# Altium schematic sheet 2002-SCM-0003-08.03 Top Sheet.SchDoc("2002-SCM-0003-08.03 Top Sheet")
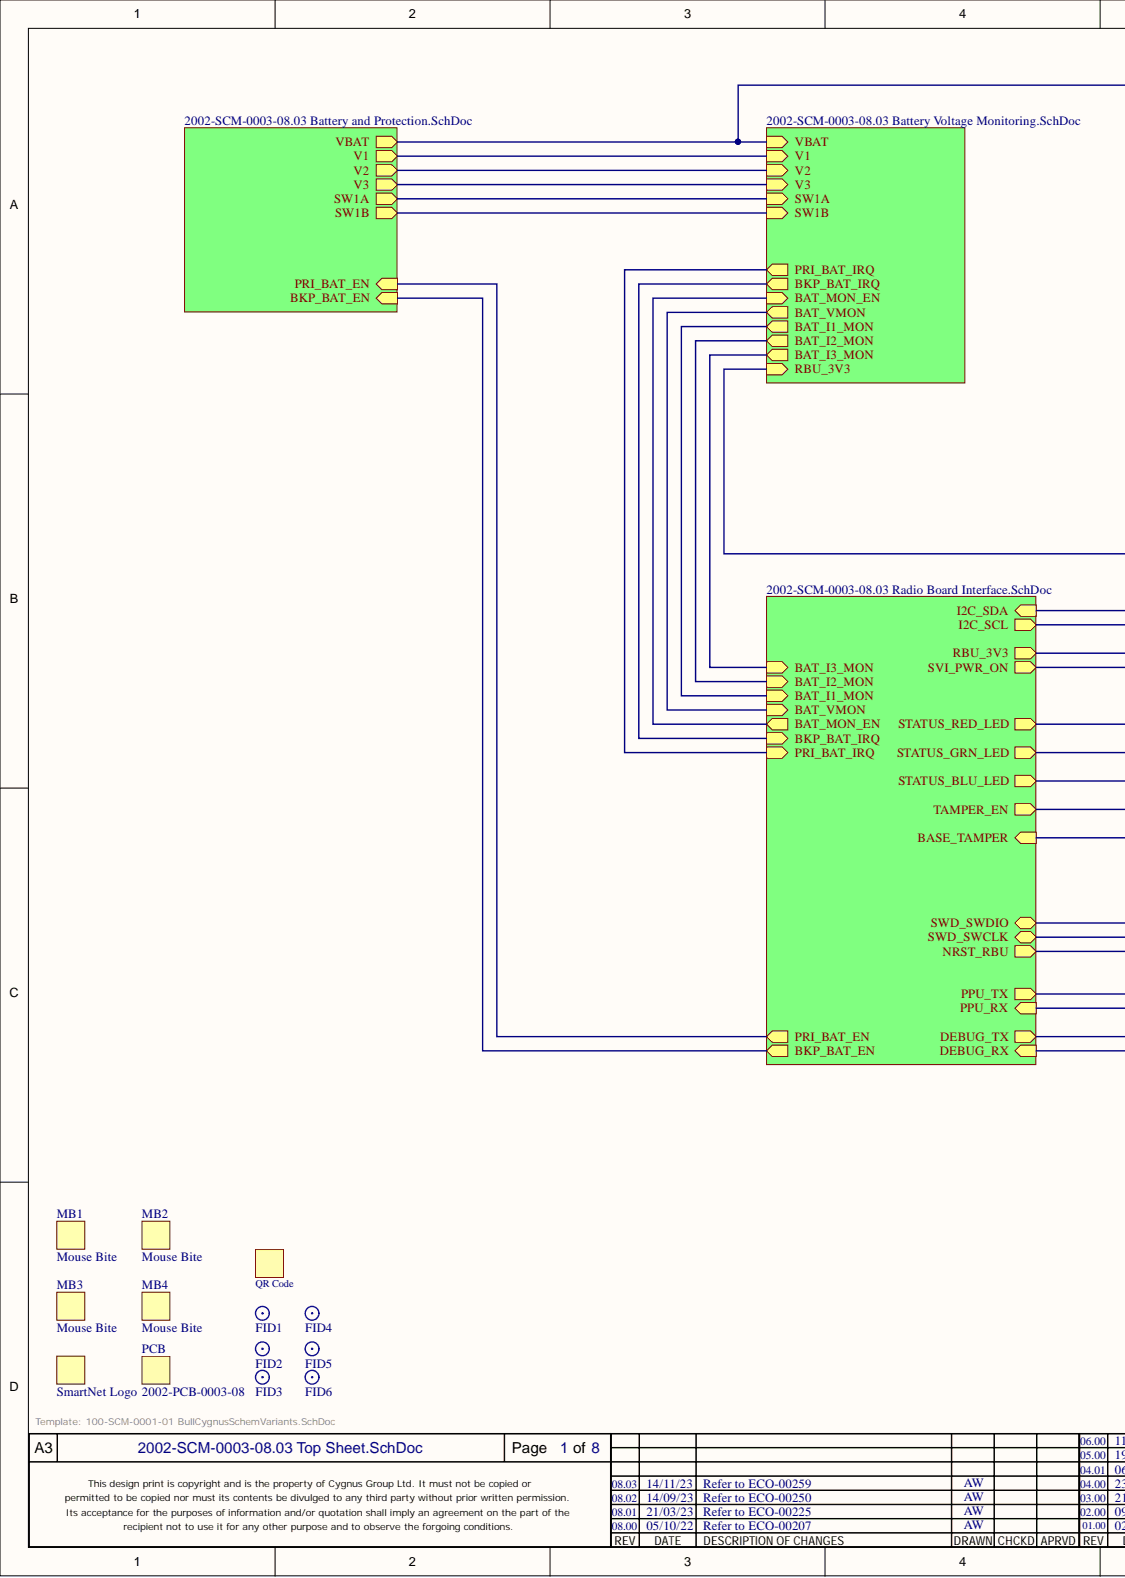
[diagram p0: 2002-SCM-0003-08.03 Top Sheet.SchDoc, left]
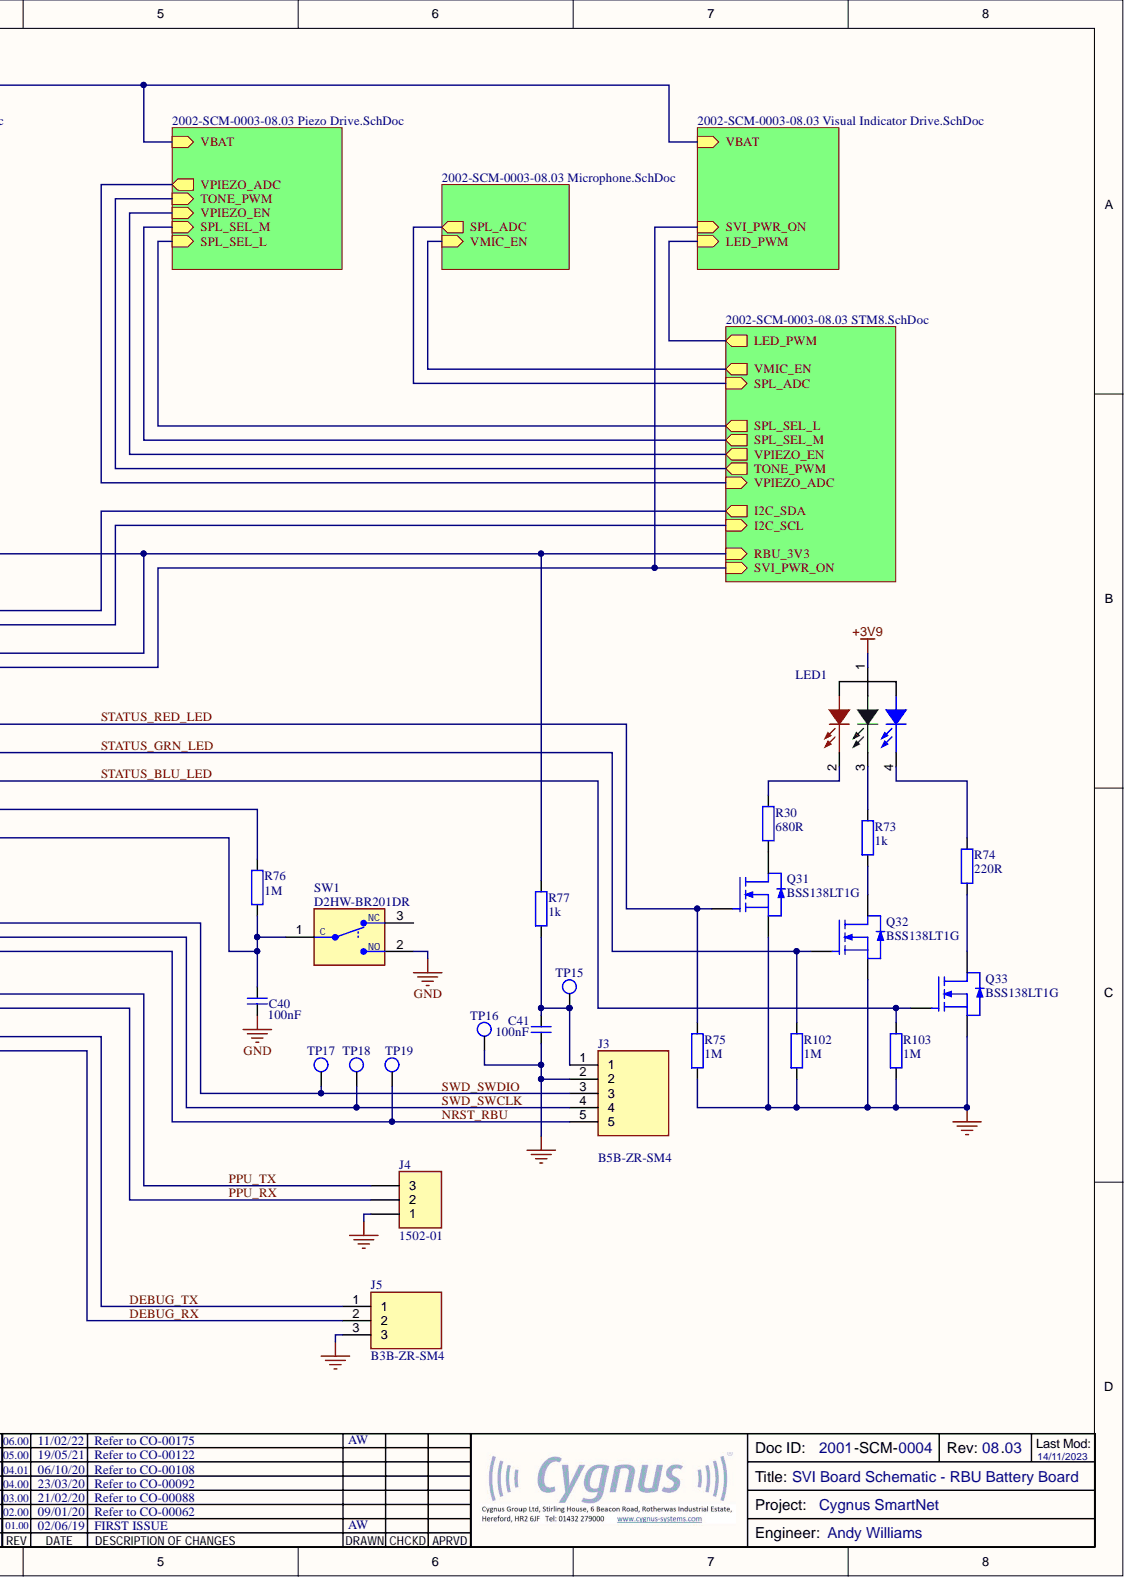
[diagram p1: 2002-SCM-0003-08.03 Top Sheet.SchDoc, right]

## Parts
C40  value 100nF  [CAPC1608X95N]  (hidden comment: 100nF 0603 50V X7R 10%)
C41  value 100nF  [CAPC1608X95N]  (hidden comment: 100nF 0603 50V X7R 10%)
FID1  FIDUCIAL-1MM  [Fiducial 1mm]  (value not drawn)  (no BOM)
FID2  FIDUCIAL-1MM  [Fiducial 1mm]  (value not drawn)  (no BOM)
FID3  FIDUCIAL-1MM  [Fiducial 1mm]  (value not drawn)  (no BOM)
FID4  FIDUCIAL-1MM  [Fiducial 1mm]  (value not drawn)  (no BOM)
FID5  FIDUCIAL-1MM  [Fiducial 1mm]  (value not drawn)  (no BOM)
FID6  FIDUCIAL-1MM  [Fiducial 1mm]  (value not drawn)  (no BOM)
J3  value B5B-ZR-SM4  [CONN-JST-B5B-ZR-SM4-TF]  (hidden comment: JST B5B ZR 5Way SMD)
J4  value 1502-01  [Jack Socket Lumberg 1502-01]  (hidden comment: Jack Socket TH V 3.5mm Lumberg 1502-01)
J5  value B3B-ZR-SM4  [CONN-JST-B3B-ZR-SM4-TF]  (hidden comment: JST B3B ZR 3Way SMD V 1P5)
Label1  SmartNet Logo  [SmartNet Logo]  (no BOM)
LED1  LED RGB PLCC4 SMD  [LED-PLCC4210x210x100]  (value not drawn)
MB1  Mouse Bite  [Mouse Bite]  (no BOM)
MB2  Mouse Bite  [Mouse Bite]  (no BOM)
MB3  Mouse Bite  [Mouse Bite]  (no BOM)
MB4  Mouse Bite  [Mouse Bite]  (no BOM)
PCB  value 2002-PCB-0003-08  (hidden comment: Bare PCB)  (mechanical)
Q31  value BSS138LT1G  [SOT-23L]  (hidden comment: BSS138LT1G N-CH 50V 0.2A SOT23)
Q32  value BSS138LT1G  [SOT-23L]  (hidden comment: BSS138LT1G N-CH 50V 0.2A SOT23)
Q33  value BSS138LT1G  [SOT-23L]  (hidden comment: BSS138LT1G N-CH 50V 0.2A SOT23)
QR_Code  PCB Laser Etched QR Code  [PCB QR CODE]  (value not drawn)  (no BOM)
R30  value 680R  [RESC1608N]  (hidden comment: 680R 0603 100mW 1%)
R73  value 1k  [RESC1608N]  (hidden comment: 1K 0603 100mW 1%)
R74  value 220R  [RESC1608N]  (hidden comment: 220R 0603 100mW 1%)
R75  value 1M  [R1608N]  (hidden comment: 1M 0603 100mW 1%)
R76  value 1M  [R1608N]  (hidden comment: 1M 0603 100mW 1%)
R77  value 1k  [RESC1608N]  (hidden comment: 1K 0603 100mW 1%)
R102  value 1M  [R1608N]  (hidden comment: 1M 0603 100mW 1%)
R103  value 1M  [R1608N]  (hidden comment: 1M 0603 100mW 1%)
SW1  value D2HW-BR201DR  [Micro-switch SPDT Omron D2HW-BR201DR]  (hidden comment: Snap Action SPCO  12V 2A TH RA Omron D2HW-BR201DR)
TP15  TESTPAD-2MM-SMT  [TESTPAD-2MM-SMT]  (value not drawn)  (no BOM)
TP16  TESTPAD-2MM-SMT  [TESTPAD-2MM-SMT]  (value not drawn)  (no BOM)
TP17  TESTPAD-2MM-SMT  [TESTPAD-2MM-SMT]  (value not drawn)  (no BOM)
TP18  TESTPAD-2MM-SMT  [TESTPAD-2MM-SMT]  (value not drawn)  (no BOM)
TP19  TESTPAD-2MM-SMT  [TESTPAD-2MM-SMT]  (value not drawn)  (no BOM)

## Nets
+3V9: LED1.1
GND: C40.1 C41.1 J3.2 J4.1 J5.3 Q31.2 Q32.2 Q33.2 R75.2 R102.2 R103.2 SW1.2 TP16.1
DEBUG_RX: J5.2
DEBUG_TX: J5.1
NRST_RBU: J3.5 TP19.1
PPU_RX: J4.2
PPU_TX: J4.3
STATUS_BLU_LED: Q33.1 R103.1
STATUS_GRN_LED: Q32.1 R102.1
STATUS_RED_LED: Q31.1 R75.1
SWD_SWCLK: J3.4 TP18.1
SWD_SWDIO: J3.3 TP17.1
NetC40_2: C40.2 R76.2 SW1.1
NetC41_2: C41.2 J3.1 R77.2 TP15.1
NetLED1_2: LED1.2 R30.1
NetLED1_3: LED1.3 R73.1
NetLED1_4: LED1.4 R74.1
NetQ31_3: Q31.3 R30.2
NetQ32_3: Q32.3 R73.2
NetQ33_3: Q33.3 R74.2
NetR76_1: R76.1
NetR77_1: R77.1
NetSW1_3: SW1.3
N00229:
N00230:
N00231:
N00232:
N00233:
N00234:
N00235:
N00236:
N00237:
N00238:
N00239:
N00240:
N00241:
N00242:
N00243:
N00244:
N00245:
N00246:
N00247:
N00248:
N00249:
N00250:
N00251:
N00252:
N00253:
N00254:

## Library data of the parts
C40 C41 = 100nF-0603-50V-X7R-10%: Voltage: 50V; Tolerance: 10%; Supplier Part Number 1: 1276-1000-2-ND; Supplier 1: DigiKey; Package: 0603; Manufacturer Part Number 1: 100nF-0603-50V-X7R-10%; Dielectric: X7R; Description: 100nF 0603 50V X7R 10%; Component Kind: Standard; Category: Ceramic; Library Name: Andy Sch Lib.SchLib
FID1-FID6 = FIDUCIAL-1MM: 1mm Fiducial with 5mm solder mask; Category: Miscellaneous; Library Name: Andy Sch Lib.SchLib
J3 = JST-B5B ZR-5Way-SMD: Temperature: -25°C ~ 85°C; Manufacturer Part Number 1: JST-B5B ZR-5Way-SMD; Manufacturer 1: JST; Description: JST B5B ZR 5Way SMD; Component Kind: Standard; Category: Header; Library Name: Andy Sch Lib.SchLib
J4 = Jack Socket TH V 3.5mm Lumberg 1502-01: Notes: Lumberg 1502-06 is an alternative; Database Table Name: Connectors; Temperature: -20°C ~ 70°C; Supplier Part Number 1: 1243242; Supplier 1: Farnell; Manufacturer Part Number 1: 1502-01; Manufacturer 1: Lumberg; Description: Jack Socket TH V 3.5mm Lumberg 1502-01; Component Kind: Standard; Category: Jack Socket; Library Name: BPL_Altium_Database_01.DbLib
J5 = JST-B3B ZR-3Way-SMD-V-1P5: Manufacturer Part Number 1: B3B-ZR-SM4-TF(LF)(SN); Temperature: -25°C ~ 85°C; Supplier Part Number 1: 455-1682-1-ND; Supplier 1: Digi-Key; Manufacturer 1: JST; Library Name: Connectors.IntLib; Description: JST B3B ZR 3Way SMD V 1P5; Component Kind: Standard; Category: Header
LED1 = LED-RGB-PLCC4-SMD: Manufacturer Part Number 1: LTSN-H213EGBW; Manufacturer 1: Lite-On; Qualified: CHECKED; Temperature: -40°C ~ 85°C; Supplier Part Number 1: 127-8409; Supplier 1: RS; Description: LED RGB PLCC4 SMD; Component Kind: Standard; Category: LED; Library Name: Andy Sch Lib.SchLib
PCB = Bare PCB: Manufacturer 1: BPL; Database Table Name: Miscellaneous; Manufacturer Part Number 1: 2002-PCB-0003-08; Library Name: BPL_Altium_Database_01.DbLib; Description: Bare PCB
Q31-Q33 = BSS138LT1G N-CH 50V 0.2A SOT23: Mosfet-N 50V 0.2A SOT23; Manufacturer 1: ON Semiconductor; Notes: BVSS138LT1G is an identical alternative; Database Table Name: Transistors; Temperature: -55°C ~ 150°C; Supplier Part Number 1: 143-1319; Supplier 1: Farnell; Package: SOT-23; Manufacturer Part Number 1: BSS138LT1G; Component Kind: Standard; Category: Mosfet; Library Name: BPL_Altium_Database_01.DbLib
QR_Code = PCB Laser Etched QR Code: Database Table Name: Miscellaneous; Critical: N; Description: PCB Laser Etched QR Code; Category: PCB Legend; Library Name: BPL_Altium_Database_01.DbLib
R30 = 680R-0603-100mW-1%: Qualified: CHECKED; Wattage: 100mW; Tolerance: 1%; Package: 0603; Manufacturer Part Number 1: 680R-0603-100mW-1%; Description: 680R 0603 100mW 1%; Component Kind: Standard; Category: Thick Film; Library Name: Andy Sch Lib.SchLib
R73 = 1K-0603-100mW-1%: Qualified: QM CHECKED; Database Table Name: Resistors; Wattage: 100mW; Tolerance: 1%; Pin Count: 2; Part Number: 1K-0603-100mW-1%; Package: 0603; Manufacturer Part Number 1: 1K-0603-100mW-1%; Family: Resistors; Designator: 1K-0603-100mW-1%; Description: 1K 0603 100mW 1%; Component Type: Standard; Component Kind: Standard; Category: Thick Film; Library Name: BPL_Altium_Database_01.DbLib; Created By - Date: QM-DEV 20190325
R74 = 220R-0603-100mW-1%: Qualified: CHECKED; Wattage: 100mW; Tolerance: 1%; Package: 0603; Manufacturer Part Number 1: 220R-0603-100mW-1%; Description: 220R 0603 100mW 1%; Component Kind: Standard; Category: Thick Film; Library Name: Andy Sch Lib.SchLib
R75 R76 R102 R103 = 1M 0603 100mW 1%: Manufacturer Part Number 1: 1M-0603-100mW-1%; Description: 1M 0603 100mW 1%; Database Table Name: Resistors 0603; Component Kind: Standard; Category: Thick Film; Library Name: BPL_Altium_Database_01.DbLib
R77 = 1K-0603-100mW-1%: Qualified: CHECKED; Wattage: 100mW; Tolerance: 1%; Package: 0603; Manufacturer Part Number 1: 1K-0603-100mW-1%; Library Name: Development.IntLib; Description: 1K 0603 100mW 1%; Component Kind: Standard; Category: Thick Film
SW1 = Snap Action SPCO  12V 2A TH RA Omron D2HW-BR201DR: Micro-switch SPCO Omron D2HW Series 12V 2A Plunger PCB Terminal TH RA; Notes: IP50; Database Table Name: Switches; Temperature: -40 °C to 85 °C; Supplier Part Number 1: D2HW-BR201DR; Supplier 1: Omron; Manufacturer Part Number 1: D2HW-BR201DR; Manufacturer 1: Omron; Component Kind: Standard; Category: Snap Action; Library Name: BPL_Altium_Database_01.DbLib
TP15-TP19 = TESTPAD-2MM-SMT: Description: TESTPAD-2MM-SMT; Category: Miscellaneous; Library Name: Andy Sch Lib.SchLib
Label1 = SmartNet Logo: Library Name: Andy Sch Lib.SchLib
MB1-MB4 = Mouse Bite: Library Name: Andy Sch Lib.SchLib
